# Altium schematic sheet XducerXface.SCHDOC(XducerXface)
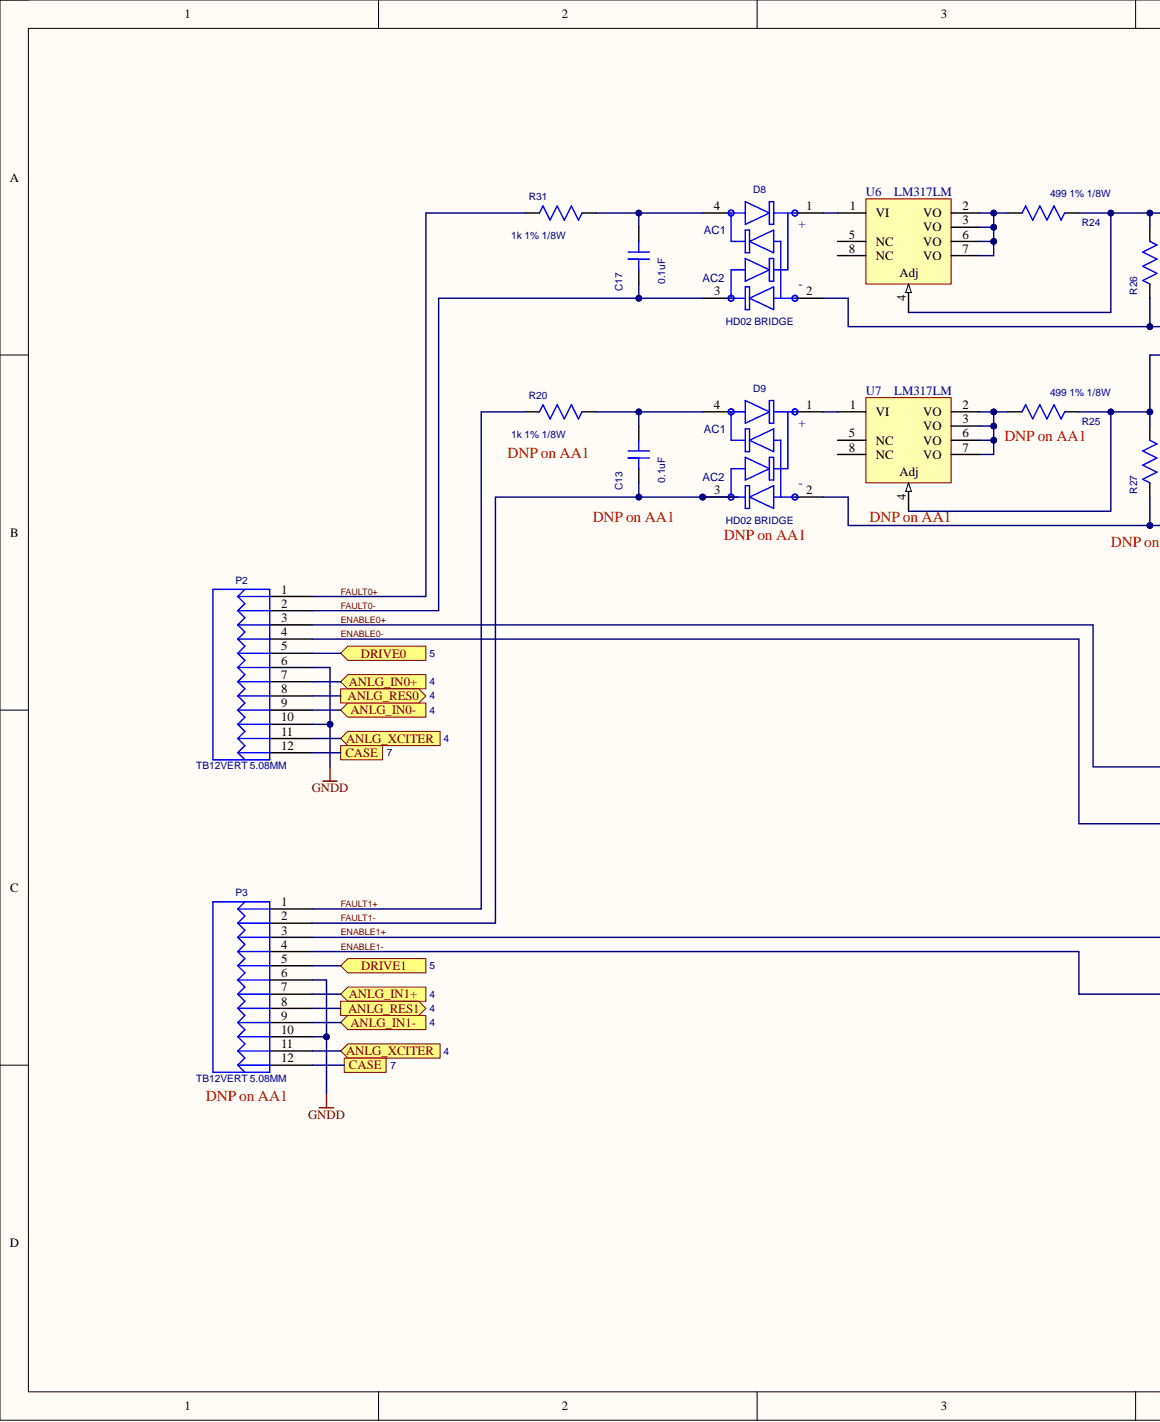
[diagram p0: XducerXface.SCHDOC, left]
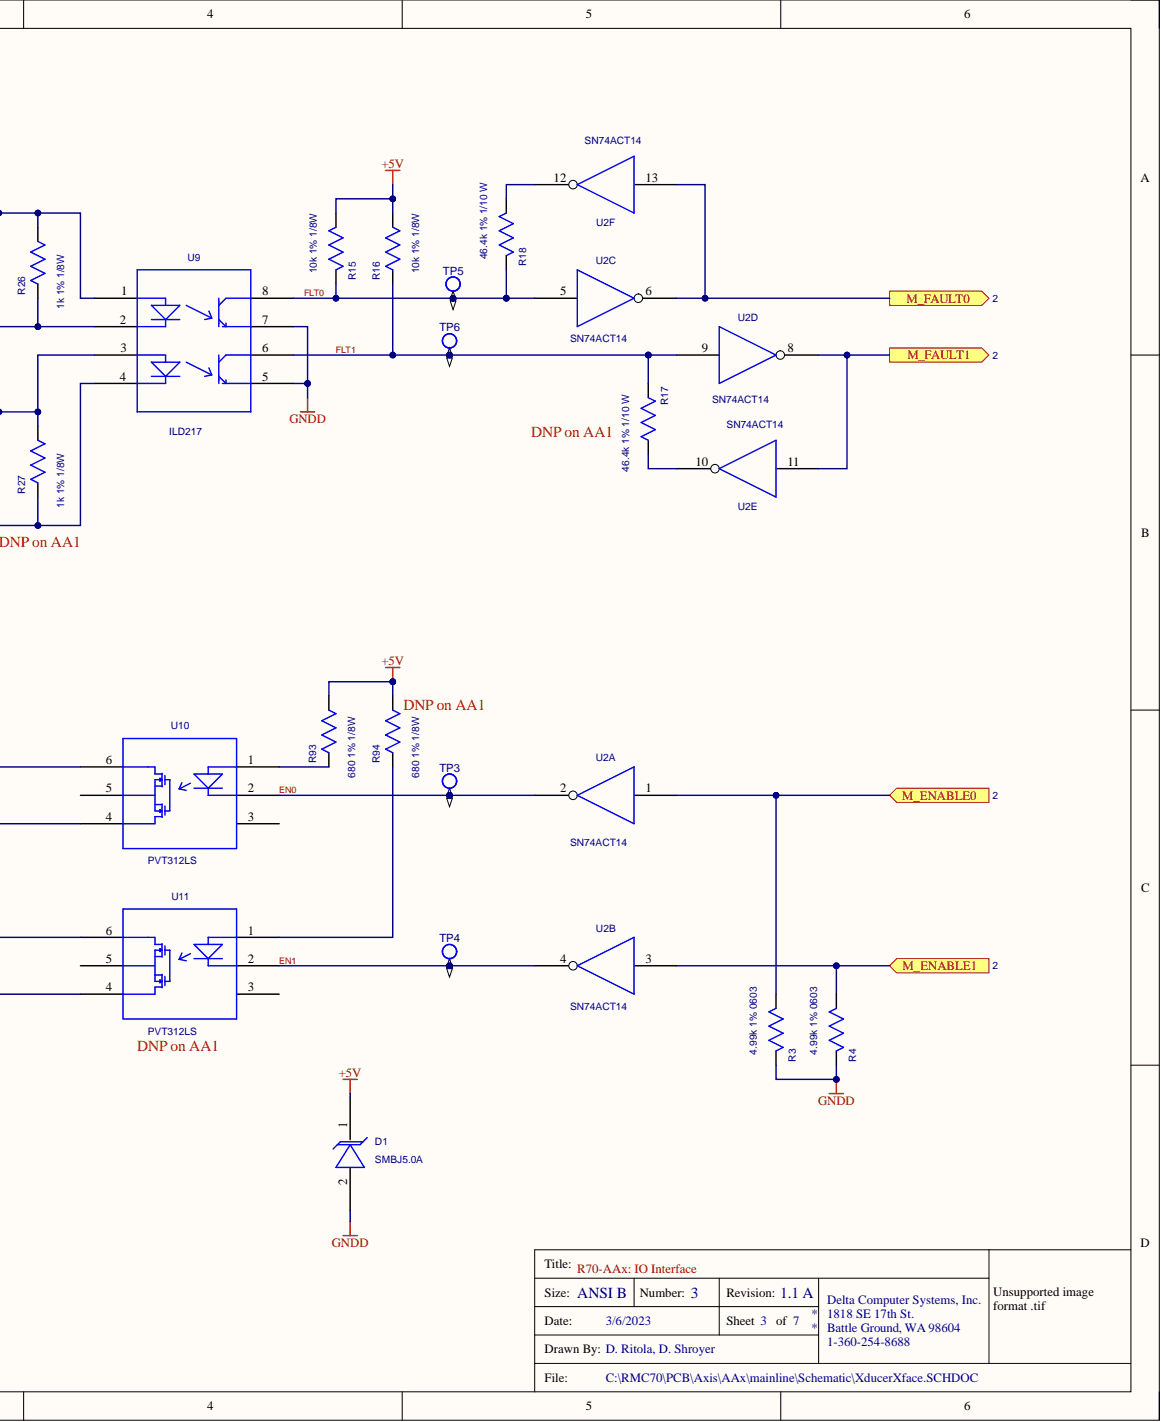
[diagram p1: XducerXface.SCHDOC, right]

## Parts
C13  0.1uF  [CC0805]
C17  0.1uF  [CC0805]
D1  SMBJ5.0A  [TRANZORBSMT]
D8  HD02 BRIDGE  [HD02 BRIDGE]
D9  HD02 BRIDGE  [HD02 BRIDGE]
P2  TB12VERT 5.08MM  [TB12VERT]
P3  TB12VERT 5.08MM  [TB12VERT]
R3  4.99k 1% 0603  [CC0603]
R4  4.99k 1% 0603  [CC0603]
R15  10k 1% 1/8W  [CC0805]
R16  10k 1% 1/8W  [CC0805]
R17  46.4k 1% 1/10 W  [CC0603]
R18  46.4k 1% 1/10 W  [CC0603]
R20  1k 1% 1/8W  [CC0805]
R24  499 1% 1/8W  [CC0805]
R25  499 1% 1/8W  [CC0805]
R26  1k 1% 1/8W  [CC0805]
R27  1k 1% 1/8W  [CC0805]
R31  1k 1% 1/8W  [CC0805]
R93  680 1% 1/8W  [CC0805]
R94  680 1% 1/8W  [CC0805]
TP3  TP1  [TESTP_XY60D40]  (value not drawn)  (no BOM)
TP4  TP1  [TESTP_XY60D40]  (value not drawn)  (no BOM)
TP5  TP1  [TESTP_XY60D40]  (value not drawn)  (no BOM)
TP6  TP1  [TESTP_XY60D40]  (value not drawn)  (no BOM)
U2  SN74ACT14  [SO14]  (parts A,B,C,D,E,F)
U6  LM317LM  [SOP-8L]
U7  LM317LM  [SOP-8L]
U9  ILD217  [SO8]
U10  value PVT312LS  [6 PIN DIP PKG SMT]  (hidden comment: HSR312-412(L))
U11  value PVT312LS  [6 PIN DIP PKG SMT]  (hidden comment: HSR312-412(L))

## Ports
ANLG_IN0+, ANLG_IN0-, ANLG_IN1+, ANLG_IN1-, ANLG_RES0, ANLG_RES1, ANLG_XCITER, CASE, DRIVE0, DRIVE1, M_ENABLE0, M_ENABLE1, M_FAULT0, M_FAULT1

## Nets
+5V: D1.1 R15.2 R16.2 R93.2 R94.2 U2.14
GNDD: D1.2 P2.6 P2.10 P3.6 P3.10 R3.1 R4.1 U2.7 U9.5 U9.7
ANLG_IN0+: P2.7 ; port ANLG_IN0+
ANLG_IN0-: P2.9 ; port ANLG_IN0-
ANLG_IN1+: P3.7 ; port ANLG_IN1+
ANLG_IN1-: P3.9 ; port ANLG_IN1-
ANLG_RES0: P2.8 ; port ANLG_RES0
ANLG_RES1: P3.8 ; port ANLG_RES1
ANLG_XCITER: P2.11 P3.11 ; port ANLG_XCITER
CASE: P2.12 P3.12 ; port CASE
DRIVE0: P2.5 ; port DRIVE0
DRIVE1: P3.5 ; port DRIVE1
EN0: TP3.1 U2.2 U10.2
EN1: TP4.1 U2.4 U11.2
ENABLE0+: P2.3 U10.6
ENABLE0-: P2.4 U10.4
ENABLE1+: P3.3 U11.6
ENABLE1-: P3.4 U11.4
FAULT0+: P2.1 R31.1
FAULT0-: C17.2 D8.3 P2.2
FAULT1+: P3.1 R20.1
FAULT1-: C13.2 D9.3 P3.2
FLT0: R15.1 R18.1 TP5.1 U2.5 U9.8
FLT1: R16.1 R17.1 TP6.1 U2.9 U9.6
M_ENABLE0: R3.2 U2.1 ; port M_ENABLE0
M_ENABLE1: R4.2 U2.3 ; port M_ENABLE1
M_FAULT0: U2.6 U2.13 ; port M_FAULT0
M_FAULT1: U2.8 U2.11 ; port M_FAULT1
NetC13_1: C13.1 D9.4 R20.2
NetC17_1: C17.1 D8.4 R31.2
NetD8_1: D8.1 U6.1(VI)
NetD8_2: D8.2 R26.1 U9.2
NetD9_1: D9.1 U7.1(VI)
NetD9_2: D9.2 R27.1 U9.4
NetR17_2: R17.2 U2.10
NetR18_2: R18.2 U2.12
NetR24_1: R24.1 R26.2 U6.4 U9.1
NetR24_2: R24.2 U6.2(VO) U6.3(VO) U6.6(VO) U6.7(VO)
NetR25_1: R25.1 R27.2 U7.4 U9.3
NetR25_2: R25.2 U7.2(VO) U7.3(VO) U7.6(VO) U7.7(VO)
NetR93_1: R93.1 U10.1
NetR94_1: R94.1 U11.1

Not connected: U6 5(NC) 8(NC); U7 5(NC) 8(NC); U10 3 5; U11 3 5

## Library data of the parts
C13 C17 = CAPNP_SMT0805: Cap Cer 0.1uF 100V 10% 0805 X7R; Manufacturer: Venkel; PartNumber: C0805X7R101-104KNP; Notes: ECA0147; Component Kind: Standard; Value: 0.1uF
D1 = TVS UNIPOLAR SMT: TVS Diode 5VWM 9.2VC SMB; Manufacturer: Fairchild; PartNumber: SMBJ5V0A; Component Kind: Standard; Value: SMBJ5.0A
D8 D9 = HD02 BRIDGE: Rectifier Bridge GP 800mA 200V 4SMD; Manufacturer: Diodes Inc; PartNumber: HD02-T; Component Kind: Standard
P2 P3 = TB12VERT 5.08MM: Header TB 5.08mm Shrouded 12p ST; Manufacturer: Metz; PartNumber: 31220112; Component Kind: Standard
R3 R4 = RES_GEN_SMT0603: Res 4.99k 1% 1/10W 0603; Manufacturer: Venkel; PartNumber: CR0603-10W-4991FT; Notes: ERA0020; Component Kind: Standard; Value: 4.99k 1% 0603
R15 R16 = RES_GEN_SMT0805: Res 10k 1% 1/8W 0805; Manufacturer: Venkel; PartNumber: CR0805-8W-1002FT; Component Kind: Standard; Value: 10k 1% 1/8W
R17 R18 = RES_GEN_SMT0603: Res 46.4k 1% 1/10W 0603; Manufacturer: Yageo; PartNumber: RC0603FR-0746K4L; Component Kind: Standard; Value: 46.4k 1% 1/10 W
R20 R26 R27 R31 = RES_GEN_SMT0805: Res 1k 1% 1/8W 0805; Manufacturer: Venkel; PartNumber: CR0805-8W-1001FT; Component Kind: Standard; Value: 1k 1% 1/8W
R24 R25 = RES_GEN_SMT0805: Res 499 1% 1/8W 0805; Manufacturer: Venkel; PartNumber: CR0805-8W-4990FT; Component Kind: Standard; Value: 499 1% 1/8W
R93 R94 = RES_GEN_SMT0805: Res 681 1% 1/8W 0805; Manufacturer: Venkel; PartNumber: CR0805-8W-6810FT; Component Kind: Standard; Value: 680 1% 1/8W
TP3-TP6 = TP1: PDIF_I_PID: TP0; PDIF_TY: 255; Description: TP1
U2 = ACT04-SO14: Inverter Hex Sch-Trig 6ch 6inp 14SOIC; Manufacturer: Fairchild; PartNumber: 74ACT14SCX; Component Kind: Standard; Value: SN74ACT14
U6 U7 = LM317LM: 100mA Adjustable Voltage Regulator; Manufacturer: Fairchild Semiconductor; Component Kind: Standard; ComponentType: Power; DateUpdated: 10/14/2010; Library Name: Power.IntLib; OutputVoltage: Adjustable; PartNumber: LM317LM; RatedCurrent: 100mA
U9 = ILD2_SMT: Optoisolator 2ch Trans 4kV 8SOIC; Manufacturer: Vishay; PartNumber: ILD217T; Component Kind: Standard; Value: ILD217
U10 U11 = HSR312-412(L): Relay Photovo SPST-NO 250V 6SMD; Manufacturer: IR; PartNumber: PVT312LSPBF; Component Kind: Standard
